# Altium schematic sheet Gatekeeper_sheet2_mcu_V2.1.SchDoc(Gatekeeper_sheet2_mcu_V2.1)
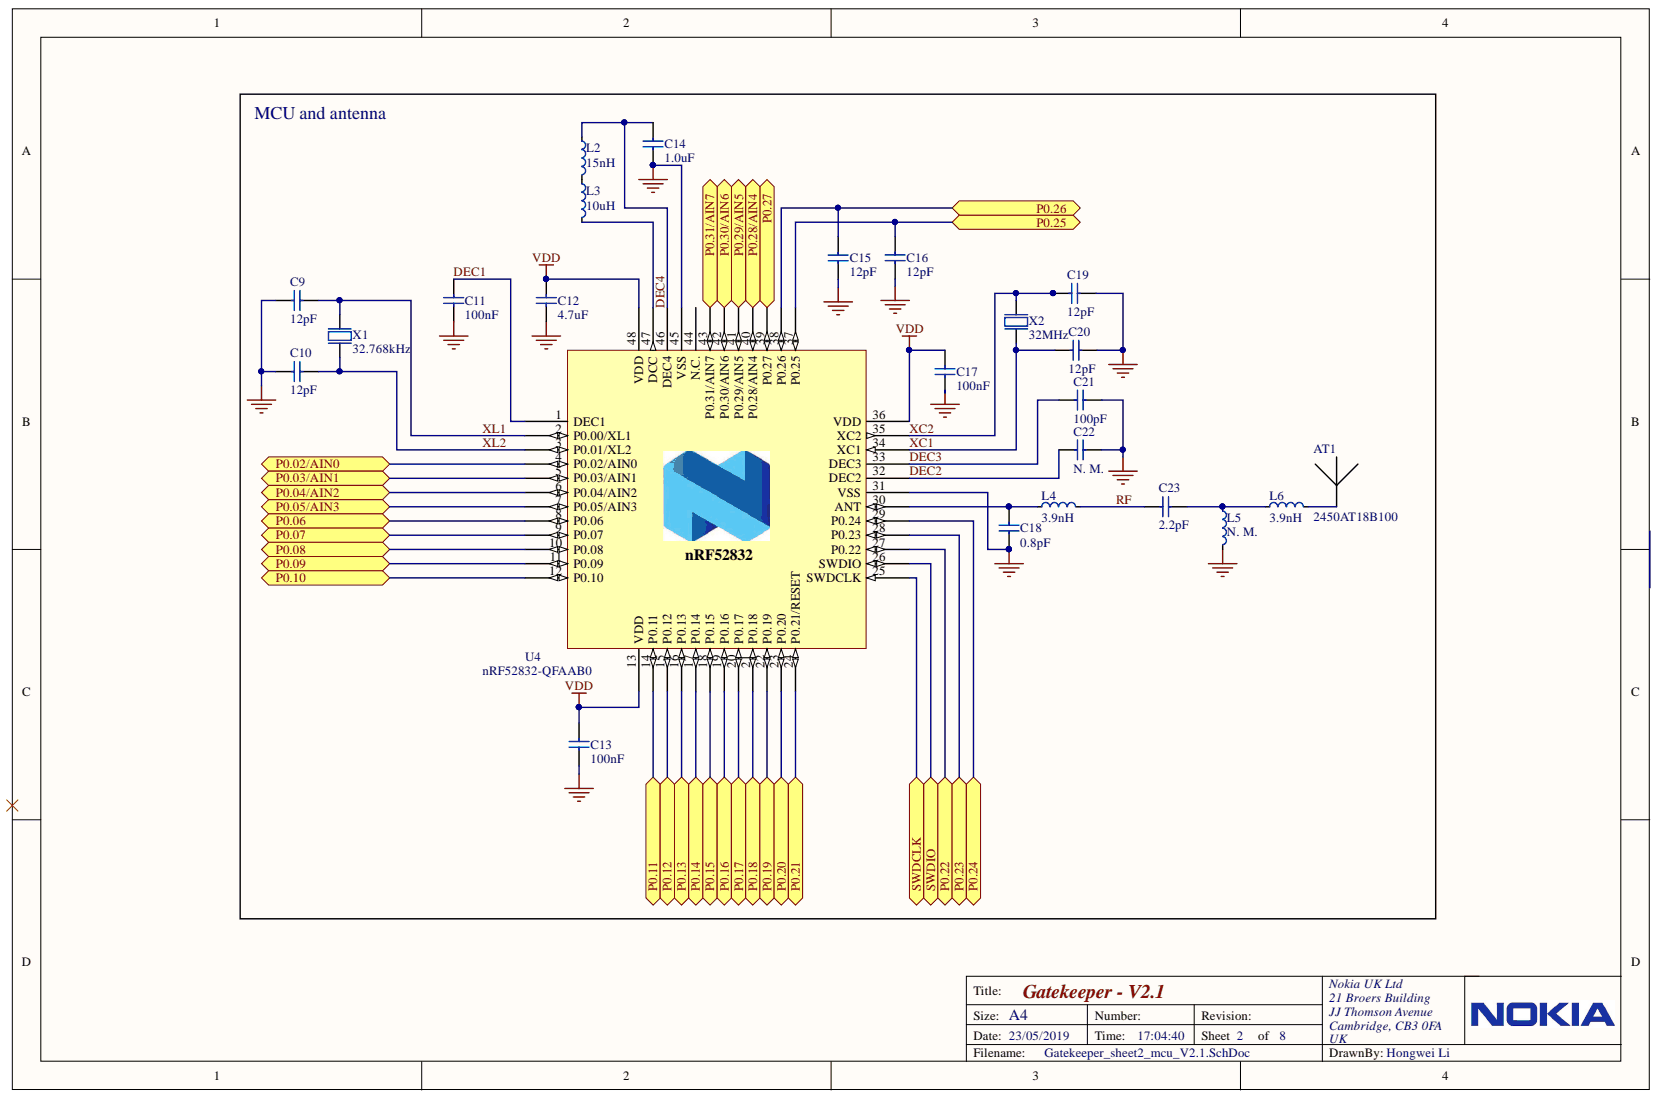
[diagram p0: Gatekeeper_sheet2_mcu_V2.1.SchDoc]

## Parts
AT1  2450AT18B100  [2450AT18B100]
C9  12pF  [CAPC1005X04L]
C10  12pF  [CAPC1005X04L]
C11  100nF  [CAPC1005X04L]
C12  4.7uF  [CAPC1608X06L]
C13  100nF  [CAPC1005X04L]
C14  1.0uF  [CAPC1608X06L]
C15  12pF  [CAPC1005X04L]
C16  12pF  [CAPC1005X04L]
C17  100nF  [CAPC1005X04L]
C18  0.8pF  [CAPC1005X04L]
C19  12pF  [CAPC1005X04L]
C20  12pF  [CAPC1005X04L]
C21  100pF  [CAPC1005X04L]
C22  N. M.  [CAPC1005X04L]  (no BOM)
C23  2.2pF  [CAPC1005X04L]
L2  15nH  [INDC1005X04L]
L3  10uH  [INDC1608X06L]
L4  3.9nH  [INDC1005X04L]
L5  N. M.  [INDC1005X04L]  (no BOM)
L6  3.9nH  [INDC1005X04L]
U4  nRF52832-QFAAB0  [QFN40P600X600X90-48N]
X1  32.768kHz  [XTAL_2012]
X2  32MHz  [BT-XTAL_2016]

## Ports
P0.02/AIN0, P0.03/AIN1, P0.04/AIN2, P0.05/AIN3, P0.06, P0.07, P0.08, P0.09, P0.10, P0.11, P0.12, P0.13, P0.14, P0.15, P0.16, P0.17, P0.18, P0.19, P0.20, P0.21, P0.22, P0.23, P0.24, P0.25, P0.26, P0.27, P0.28/AIN4, P0.29/AIN5, P0.30/AIN6, P0.31/AIN7, SWDCLK, SWDIO

## Nets
GND: C9.2 C10.2 C11.2 C12.2 C13.2 C14.1 C15.1 C16.1 C17.2 C18.1 C19.2 C20.2 C21.2 C22.1 L5.2 U4.31(VSS) U4.45(VSS) U4.49 X2.2 X2.4
VDD: C12.1 C13.1 C17.1 U4.13(VDD) U4.36(VDD) U4.48(VDD)
DEC1: C11.1 U4.1(DEC1)
DEC2: C22.2 U4.32(DEC2)
DEC3: C21.1 U4.33(DEC3)
DEC4: C14.2 L2.1 U4.46(DEC4)
P0.02/AIN0: U4.4(P0.02/AIN0) ; port P0.02/AIN0
P0.03/AIN1: U4.5(P0.03/AIN1) ; port P0.03/AIN1
P0.04/AIN2: U4.6(P0.04/AIN2) ; port P0.04/AIN2
P0.05/AIN3: U4.7(P0.05/AIN3) ; port P0.05/AIN3
P0.06: U4.8(P0.06) ; port P0.06
P0.07: U4.9(P0.07) ; port P0.07
P0.08: U4.10(P0.08) ; port P0.08
P0.09: U4.11(P0.09) ; port P0.09
P0.10: U4.12(P0.10) ; port P0.10
P0.11: U4.14(P0.11) ; port P0.11
P0.12: U4.15(P0.12) ; port P0.12
P0.13: U4.16(P0.13) ; port P0.13
P0.14: U4.17(P0.14) ; port P0.14
P0.15: U4.18(P0.15) ; port P0.15
P0.16: U4.19(P0.16) ; port P0.16
P0.17: U4.20(P0.17) ; port P0.17
P0.18: U4.21(P0.18) ; port P0.18
P0.19: U4.22(P0.19) ; port P0.19
P0.20: U4.23(P0.20) ; port P0.20
P0.21: U4.24(P0.21/RESET) ; port P0.21
P0.22: U4.27(P0.22) ; port P0.22
P0.23: U4.28(P0.23) ; port P0.23
P0.24: U4.29(P0.24) ; port P0.24
P0.25: C16.2 U4.37(P0.25) ; port P0.25
P0.26: C15.2 U4.38(P0.26) ; port P0.26
P0.27: U4.39(P0.27) ; port P0.27
P0.28/AIN4: U4.40(P0.28/AIN4) ; port P0.28/AIN4
P0.29/AIN5: U4.41(P0.29/AIN5) ; port P0.29/AIN5
P0.30/AIN6: U4.42(P0.30/AIN6) ; port P0.30/AIN6
P0.31/AIN7: U4.43(P0.31/AIN7) ; port P0.31/AIN7
RF: C23.2 L4.2
SWDCLK: U4.25(SWDCLK) ; port SWDCLK
SWDIO: U4.26(SWDIO) ; port SWDIO
XC1: C20.1 U4.34(XC1) X2.1
XC2: C19.1 U4.35(XC2) X2.3
XL1: C9.1 U4.2(P0.00/XL1) X1.1
XL2: C10.1 U4.3(P0.01/XL2) X1.2
NetAT1_1: AT1.1 L6.2
NetC18_2: C18.2 L4.1 U4.30(ANT)
NetC23_1: C23.1 L5.1 L6.1
NetL2_2: L2.2 L3.1
NetL3_2: L3.2 U4.47(DCC)
N00006:

Not connected: U4 44(N.C.)

## Library data of the parts
AT1 = Antenna: 2.45 GHz chip antenna; Part number IPS: 00000007781; Part Number: Johanson 2450AT18B100; Manufacturer: Johanson Technology; 2nd source part number: 712-1006-1-ND; Value: 2450AT18B100; Supplier part number: 609-2450AT18B100E; Supplier: Mouser; Published: 2013-09-13; Pin Count: 2; Manufacturer Part Number: 2450AT18B100; LatestRevisionDate: 2013-09-13; Footprint Doc: 2450AT18B100; Datasheet: http://www.johansontechnology.com/datasheets/antennas/2450AT18B100.pdf; Component Kind: Standard; 2nd source Supplier: DigiKey; Library Name: Gatekeeper_V2.1.SchLib
C9 C10 C15 C16 C19 C20 = (no symbol name): Capacitor, 0402, NP0, ±2%; Part number IPS: 00000002398; Part Number: C0402; Manufacturer Part Number: GJM1555C1H120GB01D; Manufacturer: Murata Electronics; 2nd source part number: 603-CC402GRNPO9BN120; Note: 50V; Value: 12pF; Supplier part number: 81-GJM1555C1H120GB01; Supplier: Mouser; Pin Count: 2; Footprint Doc: 0402; Component Kind: Standard; 2nd source Supplier: Mouser; Library Name: Gatekeeper.SCHLIB
C11 C13 C17 = (no symbol name): Capacitor, 0402, X7R, ±10%; Part Number: C0402; Manufacturer Part Number: 885012205037; Manufacturer: Wurth Electronics; 2nd source part number: 81-GCM155R71C104KA5D; Note: 16V; Value: 100nF; Supplier part number: 710-885012205037; Supplier: Mouser; Pin Count: 2; Footprint Doc: 0402; Datasheet: https://www.mouser.co.uk/datasheet/2/445/885012205037-554188.pdf; Component Kind: Standard; 2nd source Supplier: Mouser; Library Name: Gatekeeper.SCHLIB
C12 = CAP_0603: Capacitor, 0603, X5R, ±10%; Part Number: C0603-4µ7; Manufacturer Part Number: GCJ188C70J475KE02D; Manufacturer: Murata Electronics; 2nd source part number: 490-16480-1-ND; Note: 6.3V; Value: 4.7uF; Supplier part number: 81-GCJ188C70J475KE2D; Supplier: Mouser; Pin Count: 2; Footprint Doc: 0603; Component Kind: Standard; 2nd source Supplier: DigiKey; Library Name: Gatekeeper_V2.1.SCHLIB
C14 = (no symbol name): Capacitor, 0603, X7R, ±10%; Part Number: C0603-1µ0; Manufacturer Part Number: JMK107B7105KA-T; Manufacturer: Taiyo Yuden; 2nd source part number: 710-885012206002; Note: 6.3V; Value: 1.0uF; Supplier part number: 963-JMK107B7105KA-T; Supplier: Mouser; Pin Count: 2; Footprint Doc: 0603; Component Kind: Standard; 2nd source Supplier: Mouser; Library Name: Gatekeeper.SCHLIB
C18 = C0402-0.8p: Capacitor, NP0, ±5%; Manufacturer Part Number: GJM1555C1HR80WB01D; Manufacturer: Murata Electronics; 2nd source part number: 609-500R07S0R8AV4T; Note: 50V; Database Table Name: Capacitors$; Value: 0.8pF; Supplier part number: 81-GJM1555C1HR80WB1D; Supplier: Mouser; Pin Count: 2; Part Number: C0402-0.8p; Library Name: Nordic Passive DB.DbLib; Footprint Doc: 0402; Component Kind: Standard; 2nd source Supplier: Mouser
C21 = (no symbol name): Capacitor, 0402, NP0, ±5%; Part Number: C0402; Manufacturer Part Number: GRM0225C1E101JA2L; Manufacturer: Murata Electronics; 2nd source part number: 581-04023A101JAT2A; Note: 25V; Value: 100pF; Supplier part number: 81-GRM0225C1E101JA2L; Supplier: Mouser; Pin Count: 2; LatestRevisionDate: 2011-05-11; Footprint Doc: 0402; Component Kind: Standard; 2nd source Supplier: Mouser; Library Name: Gatekeeper.SCHLIB
C22 = C0402-N.C.: Additional capacitor, Not Mounted; Note: Chip Cap; Assembly: Not Mounted; Value: N. M.; Pin Count: 2; Part Number: C0402-N.C.; Library Name: pca20020.SCHLIB; LatestRevisionDate: 2009-05-08; Footprint Doc: 0402
C23 = (no symbol name): Capacitor, 0402, NP0, ±0.1pF; Part number IPS: 00000002398; Part Number: C0402; Manufacturer Part Number: GJM1555C1H2R2BB1J; Manufacturer: Murata Electronics; 2nd source part number: 81-GJM1555C1H2R2BB01; Note: 50V; Value: 2.2pF; Supplier part number: 81-GJM1555C1H2R2BB1J; Supplier: Mouser; Pin Count: 2; Footprint Doc: 0402; Component Kind: Standard; 2nd source Supplier: Mouser; Library Name: Gatekeeper.SCHLIB
L2 = IND_0402_15nH: Inductor, 0402, 320mA, ±5%; Part Number: L0402-15n; Manufacturer part number: HK100515NJ-T; Manufacturer: Taiyo Yuden; 2nd source part number: 658-1328; Note: High frequency chip inductor ±10%; Value: 15nH; Supplier part number: 963-HK100515NJ-T; Supplier: Mouser; Pin Count: 2; Footprint Doc: 0402; Datasheet: http://www.yuden.co.jp/productdata/catalog/en/mlci04_e.pdf; ComponentLink2Description: Supplier link; ComponentLink1Description: Datasheet; Component Kind: Standard; 3rd supplier part number: 587-1521-1-ND; 3rd source supplier: DigiKey; 2nd source Supplier: Farnell; Library Name: Gatekeeper_V2.1.SCHLIB
L3 = (no symbol name): Inductor, 0603, 80mA, ±10%; Part number IPS: 00000007794; Part Number: L0603-10µ; Manufacturer Part Number: LBMF1608T100K; Manufacturer: Taiyo Yuden; 2nd source part number: 178-6105; Note: LBMF1608T100K 10µH 80mA 0603; Value: 10uH; Supplier part number: 963-LBMF1608T100K; Supplier: Mouser; Pin Count: 2; LatestRevisionDate: 2012-09-19; Footprint Doc: 0603; Datasheet: http://www.yuden.co.jp/ut/product/pdf/elb2_e.pdf; ComponentLink2Description: Supplier link; ComponentLink1Description: Datasheet; Component Kind: Standard; 2nd source Supplier: Farnell; Library Name: Gatekeeper_V2.1.SchLib
L4 L6 = IND_0402: Inductor, 170mA, ±0.1nH; Part number IPS: 00000007793; Part Number: L0402-3n9; Manufacturer Part Number: LQP15MN3N9B02D; Manufacturer: Murata; 2nd source part number: 490-1131-1-ND; Note: High frequency chip inductor ±5%; Value: 3.9nH; Supplier part number: 81-LQP15MN3N9B02D; Supplier: Mouser; Pin Count: 2; LatestRevisionDate: 2012-09-19; Footprint Doc: 0402; Datasheet: http://search.murata.co.jp/Ceramy/image/img/PDF/ENG/L0075S0084LQP15M.pdf; ComponentLink2Description: Supplier link; ComponentLink1Description: Datasheet; Component Kind: Standard; 2nd source Supplier: DigiKey; Library Name: Gatekeeper.IntLib
L5 = IND_0402: Additional inductor, Not Mounted; 2nd source part number: 658-1328; Assembly: Not Mounted; Value: N. M.; Pin Count: 2; Footprint Doc: 0402; ComponentLink2Description: Supplier link; ComponentLink1Description: Datasheet; 2nd source Supplier: Farnell; Library Name: Gatekeeper_V2.1.SCHLIB
U4 = nRF52832_QFAA: Multi-protocol Bluetooth Low Energy, ANT and 2.4GHz proprietary system-on-chip; Manufacturer Part Number: nRF52832-QFAA-R; Manufacturer: Nordic Semiconductor; 2nd source part number: 1490-1052-1-ND; Value: nRF52832-QFAAB0; Supplier part number: 949-NRF52832-QFAA-R; Supplier: Mouser; Published: 2016-08-25; Pin Count: 48; LatestRevisionDate: 2016-08-25; Footprint Doc: QFN-48; Component Kind: Standard; 2nd source Supplier: Digikey; Library Name: Gatekeeper.IntLib
X1 = XTAL_2-pin_SMD2012: XTAL SMD 2012, 32.768kHz, 9pF, ±20ppm; Manufacturer Part Number: CM8V-T1A-32.768kHz-9pF-20PPM-TA-QC; Manufacturer: Micro Crystal; Note: SMD 32.768kHz; Value: 32.768kHz; Supplier part number: 428-201410-MC01; Supplier: Mouser; Pin Count: 2; LatestRevisionDate: 2017-01-30; Footprint Doc: XTAL_2012; Datasheet: http://www.microcrystal.com/images/_PDF/3_Crystal_Ceramic-Package/cm8v-t1a.pdf; Component Kind: Standard; Library Name: Gatekeeper_V2.1.SCHLIB
X2 = XTAL_4-pin_SMD2016: XTAL SMD 2016, 32MHz, Cl=8pF, Tot: ±40ppm; Part Number: XTAL_SMD2016_32MHz_8pF_Kyocera; Manufacturer Part Number: CX2016DB32000D0WZRC1; Manufacturer: Kyocera; 2nd source part number: 1253-1586-1-ND; Note: XTAL SMD 32.000MHz; Value: 32MHz; Supplier part number: 581-CX2016DB32DWZRC1; Supplier: Mouser; Pin Count: 4; Footprint Doc: XTAL_2016; Datasheet: http://media.digikey.com/pdf/Data%20Sheets/AVX%20PDFs/CX2016DB32000D0WZRC1_Spec.pdf; Component Kind: Standard; 2nd source Supplier: DigiKey; Library Name: Gatekeeper_V2.1.SchLib
